# Altium schematic sheet 3_Phase_Amp.SchDoc
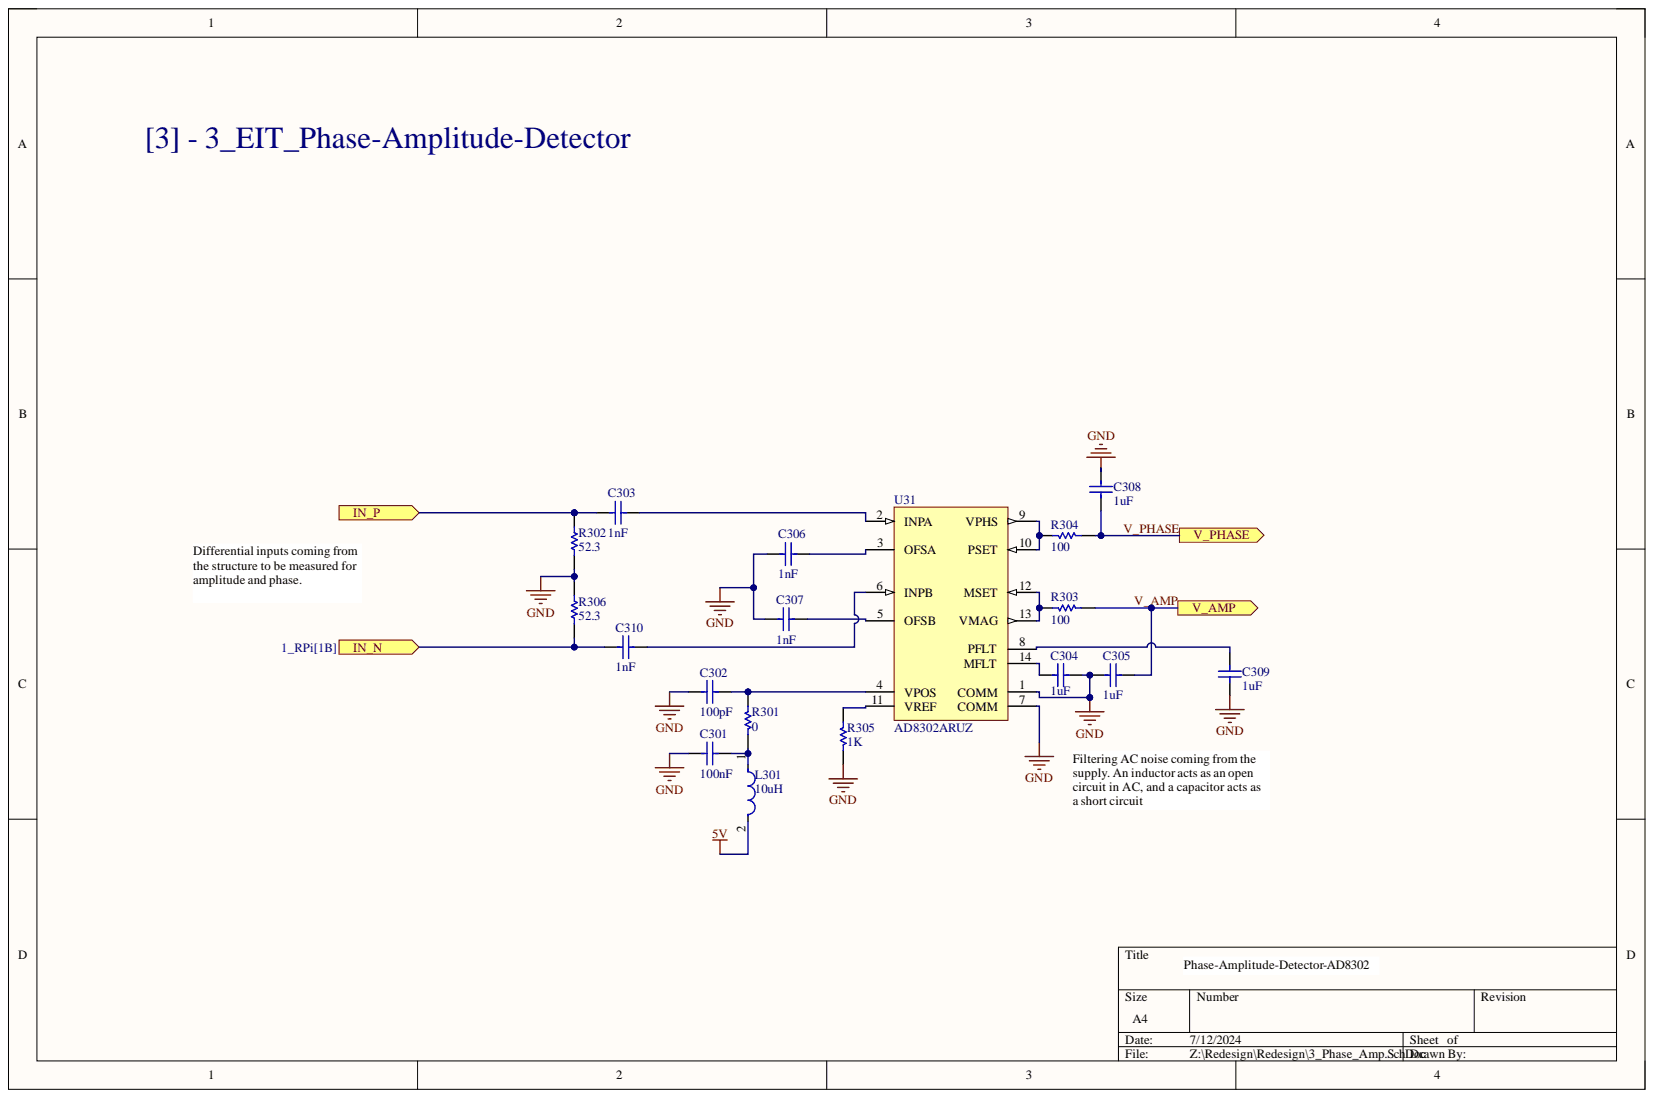
[diagram p0: 3_Phase_Amp.SchDoc]

## Parts
C301  value 100nF  [CAPC1005X55X30LL05T10]  (hidden comment: generic)
C302  value 100pF  [CAPC1005X55X30LL05T10]  (hidden comment: generic)
C303  value 1nF  [CAPC1005X55X30LL05T10]  (hidden comment: generic)
C304  value 1uF  [CAPC1005X55X30LL05T10]  (hidden comment: generic)
C305  value 1uF  [CAPC1005X55X30LL05T10]  (hidden comment: generic)
C306  value 1nF  [CAPC1005X55X30LL05T10]  (hidden comment: generic)
C307  value 1nF  [CAPC1005X55X30LL05T10]  (hidden comment: generic)
C308  value 1uF  [CAPC1005X55X30LL05T10]  (hidden comment: generic)
C309  value 1uF  [CAPC1005X55X30LL05T10]  (hidden comment: generic)
C310  value 1nF  [CAPC1005X55X30LL05T10]  (hidden comment: generic)
L301  Inductance: 10uH  [IND_0402HL-821XJRU]  (hidden comment: LQW18AN10NG00D)
R301  0  [RESC1005X40X25LL10T10]
R302  52.3  [RESC1005X40X25LL10T10]
R303  100  [RESC1005X40X25LL10T10]
R304  100  [RESC1005X40X25LL10T10]
R305  1K  [RESC1005X40X25LL10T10]
R306  52.3  [RESC1005X40X25LL10T10]
U31  AD8302ARUZ  [ADI-RU-14_L]

## Ports
IN_N, IN_P, V_AMP, V_PHASE

## Nets
5V: L301.2
GND: C301.1 C302.1 C304.1 C305.1 C306.2 C307.2 C308.1 C309.1 R302.1 R305.1 R306.2 U31.1(COMM) U31.7(COMM)
IN_N: C310.2 R306.1 ; port IN_N
IN_P: C303.1 R302.2 ; port IN_P
V_AMP: C305.2 R303.2 ; port V_AMP
V_PHASE: C308.2 R304.2 ; port V_PHASE
NetC301_2: C301.2 L301.1 R301.2
NetC302_2: C302.2 R301.1 U31.4(VPOS)
NetC303_2: C303.2 U31.2(INPA)
NetC304_2: C304.2 U31.14(MFLT)
NetC306_1: C306.1 U31.3(OFSA)
NetC307_1: C307.1 U31.5(OFSB)
NetC309_2: C309.2 U31.8(PFLT)
NetC310_1: C310.1 U31.6(INPB)
NetR303_1: R303.1 U31.12(MSET) U31.13(VMAG)
NetR304_1: R304.1 U31.9(VPHS) U31.10(PSET)
NetR305_2: R305.2 U31.11(VREF)

## Library data of the parts
C301-C310 = Capacitor: Component Kind: Standard; Description: generic; Device Class L1: Passive Components; Device Class L2: Capacitors; Library Name: RPI_EIT_SchLib.SchLib
L301 = 725321dda6339637d4d059423bc1c3c: Wire Wound RF Inductor 10nH ±2% 650mA 0.11Ω 0603 (1608); Current: 550mA; DC Resistance (DCR): 110mR; Q Factor: 35; Self Resonant Frequency: 6GHz; Shielding: Unshielded; Test Frequency: 100MHz; Case Code (Imperial): 0603; Case Code (Metric): 1608; Case/Package: 0603; Component Kind: Standard; Current Rating: 650mA; DC Current: 650mA; Depth: 1mm; Height: 0.8mm; Inductance Tolerance: 2%; Lead Free: Lead Free; Length: 1.6mm; Max DC Current: 650mA; Max Operating Temperature: 125°C; Military Standard: Not; Min Operating Temperature: -55°C; Mount: Surface Mount; Number of Pins: 2; Package Quantity: 4000; Packaging: Tape and Reel; Radiation Hardening: No; Rated Current-Max: 650mA; REACH SVHC: No SVHC; Resistor Type: Wirewound; Series: LQW18A_00; Series Resistance: 110mR; Termination: SMD/SMT; Tolerance: 2%; Weight: 0.000106oz; Width: 0.8mm; Library Name: RPI_EIT_SchLib.SchLib
R301-R306 = Resistor: generic; Manufacturer: Yageo / Phycomp; Part Number: RC0402FR-0747KL; Component Kind: Standard; Library Name: RPI_EIT_SchLib.SchLib
U31 = CMP-0284-00076-1: LF-2.7 GHz RF/IF Gain and Phase Detector, 60ns Response Time, RU-14, Pb-Free, 96 Tube; Dynamic Range (dB): 60dB; Product Description: Dual-Channel Gain and Phase Outputs; RF Frequency (MHz): 2700; Supply Current: 19mA; Temp Stability (dB): 1dB; Voltage Supply (V): 2.7 to 5.5; Code_JEDEC: MO-153AB-1; Component Kind: Standard; ComponentLink1Description: Manufacturer URL; ComponentLink1URL: www.analog.com; ComponentLink2Description: Datasheet; ComponentLink2URL: http://www.analog.com/static/imported-files/data_sheets/AD8302.pdf; ComponentLink3Description: Package Specification; ComponentLink3URL: http://www.analog.com/static/imported-files/packages/36057385283879153717235186773RU14.pdf; DatasheetVersion: Rev. A; Library Name: Altium Content Vault; PackageDescription: 14-Lead Thin Shrink Small Outline Package [TSSOP]; PackageReference: RU-14; PackageVersion: Aug-2002; Response Time (ns typ): 60ns
